# Altium schematic sheet communication.SchDoc(communication)
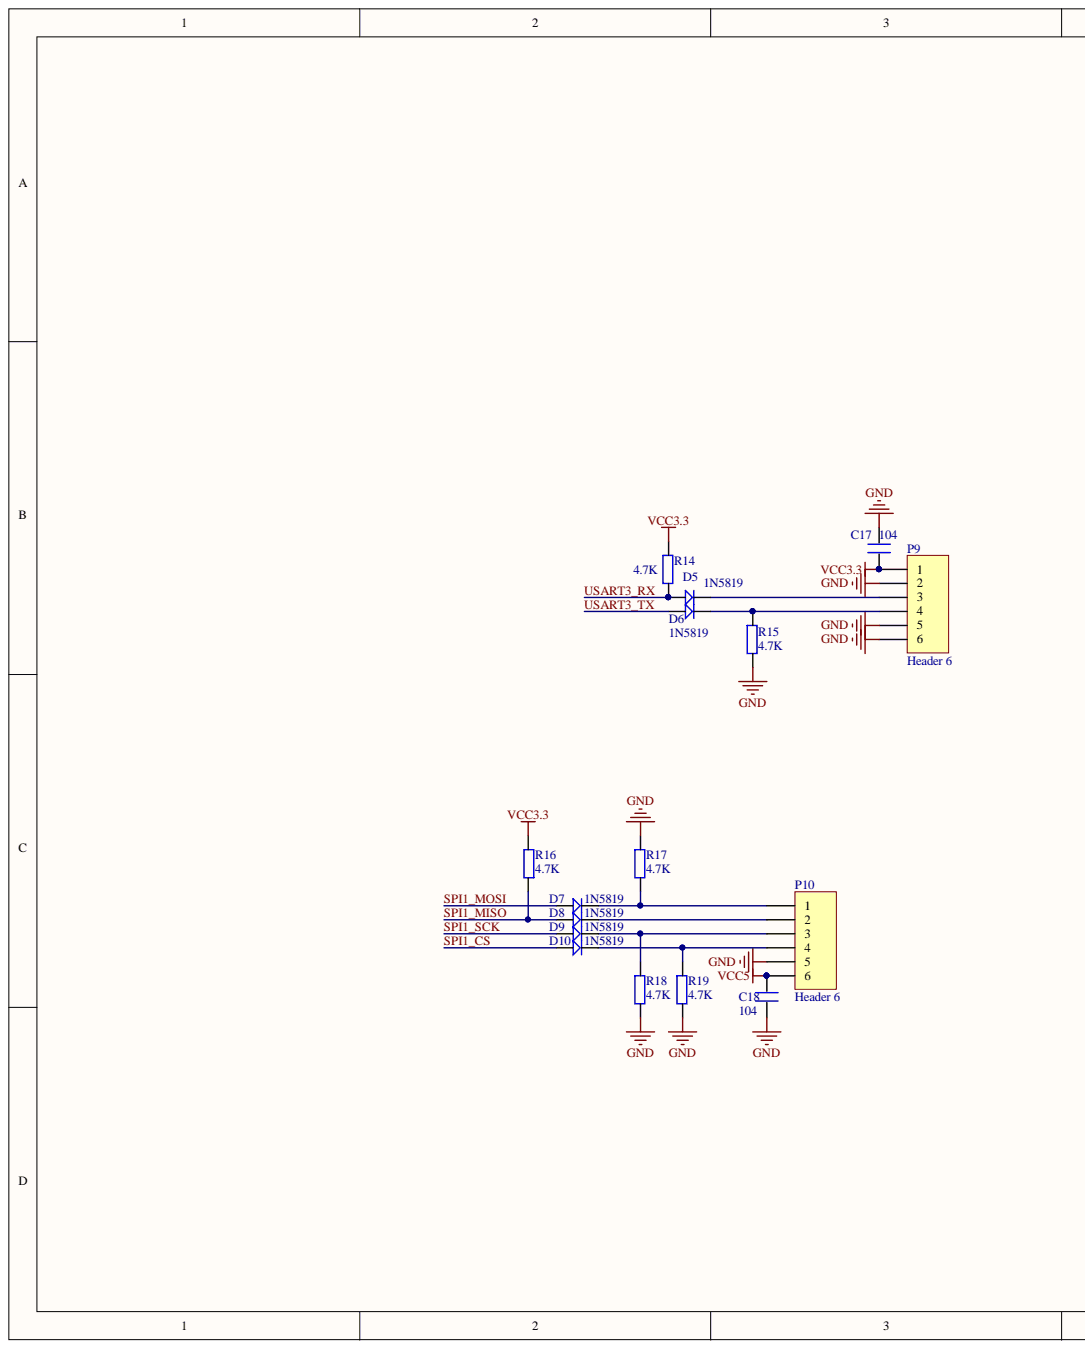
[diagram p0: communication.SchDoc, left]
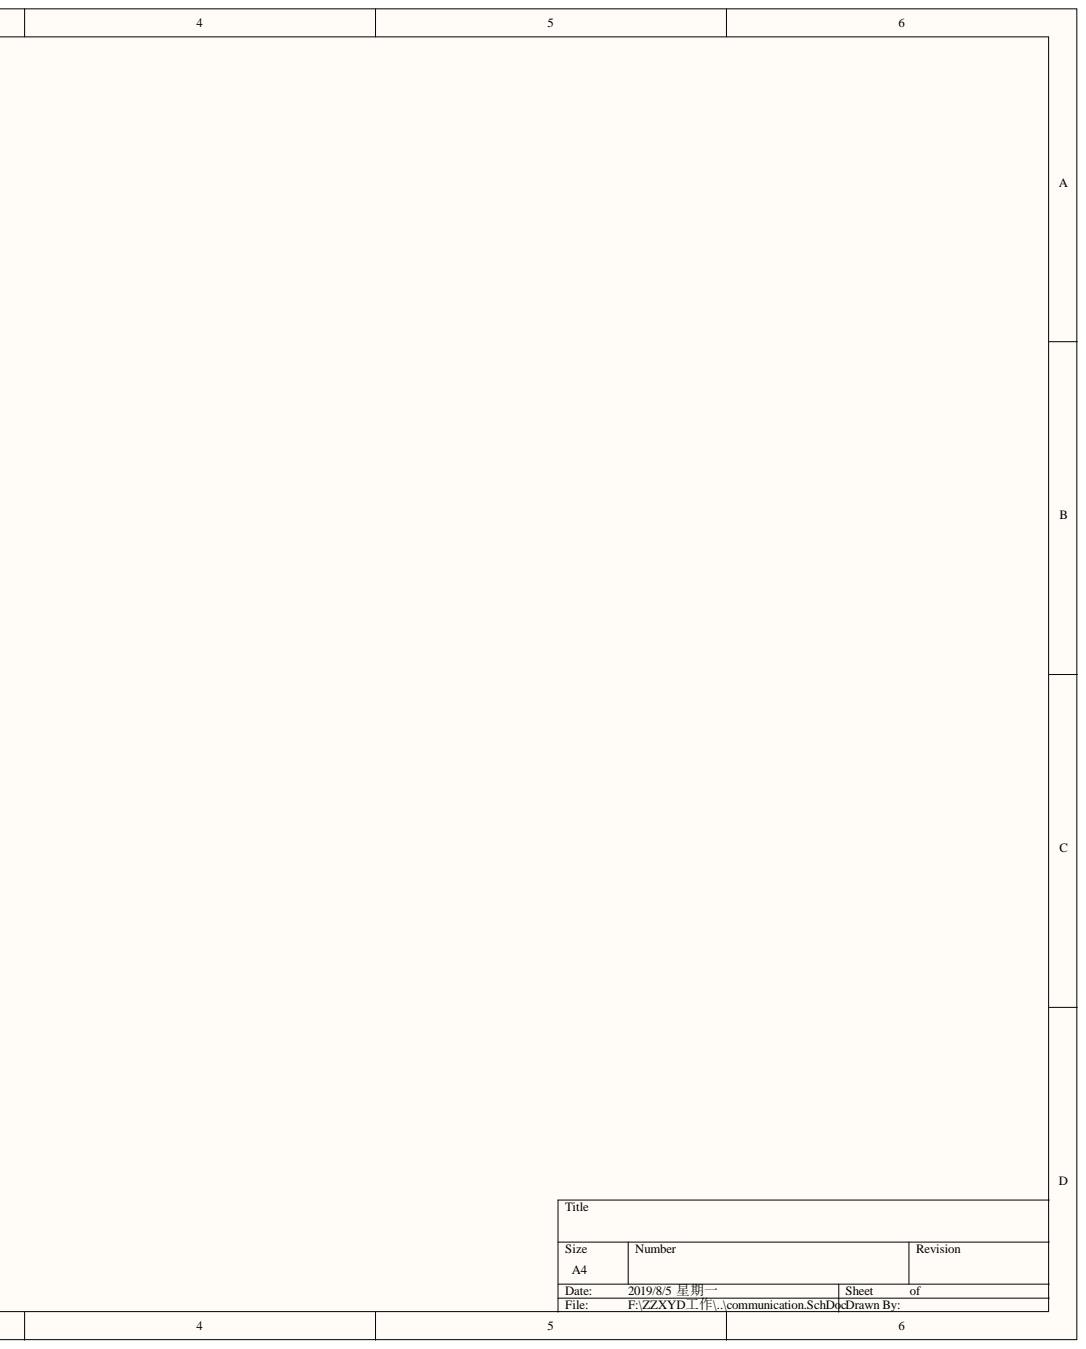
[diagram p1: communication.SchDoc, right]

## Parts
C17  C  value 104  [0805C]
C18  C  value 104  [0805C]
D5  1N5819  [1N5819]
D6  1N5819  [1N5819]
D7  1N5819  [1N5819]
D8  1N5819  [1N5819]
D9  1N5819  [1N5819]
D10  1N5819  [1N5819]
P9  Header 6  [HDR1X6]
P10  Header 6  [HDR1X6]
R14  value 4.7K  [0805R]  (hidden comment: RES)
R15  value 4.7K  [0805R]  (hidden comment: RES)
R16  value 4.7K  [0805R]  (hidden comment: RES)
R17  value 4.7K  [0805R]  (hidden comment: RES)
R18  value 4.7K  [0805R]  (hidden comment: RES)
R19  value 4.7K  [0805R]  (hidden comment: RES)

## Nets
GND: C17.2 C18.1 P9.2 P9.5 P9.6 P10.5 R15.2 R17.1 R18.2 R19.2
VCC3.3: C17.1 P9.1 R14.1 R16.2
VCC5: C18.2 P10.6
SPI1_CS: D10.1
SPI1_MISO: D8.1 R16.1
SPI1_MOSI: D7.1
SPI1_SCK: D9.1
USART3_RX: D5.1 R14.2
USART3_TX: D6.1
NetD5_2: D5.2 P9.3
NetD6_2: D6.2 P9.4 R15.1
NetD7_2: D7.2 P10.1 R17.2
NetD8_2: D8.2 P10.2
NetD9_2: D9.2 P10.3 R18.1
NetD10_2: D10.2 P10.4 R19.1

## Library data of the parts
C17 C18 = C: CAP; Component Kind: Standard; Library Name: 主控端最小系统.SCHLIB
D5-D10 = D: Library Name: WarShip V3 STM32103封装库.IntLib; Component Kind: Standard
P9 P10 = Header 6: Header, 6-Pin; Signal Integrity: Connector; Library Name: Miscellaneous Connectors.IntLib; LatestRevisionNote: Re-released for DXP Platform.; LatestRevisionDate: 17-Jul-2002; Component Kind: Standard; Publisher: Altium Limited
R14-R19 = RES: Description: RES; Component Kind: Standard; Library Name: 主控端最小系统.SCHLIB
